# Altium schematic sheet Sheet3.SchDoc
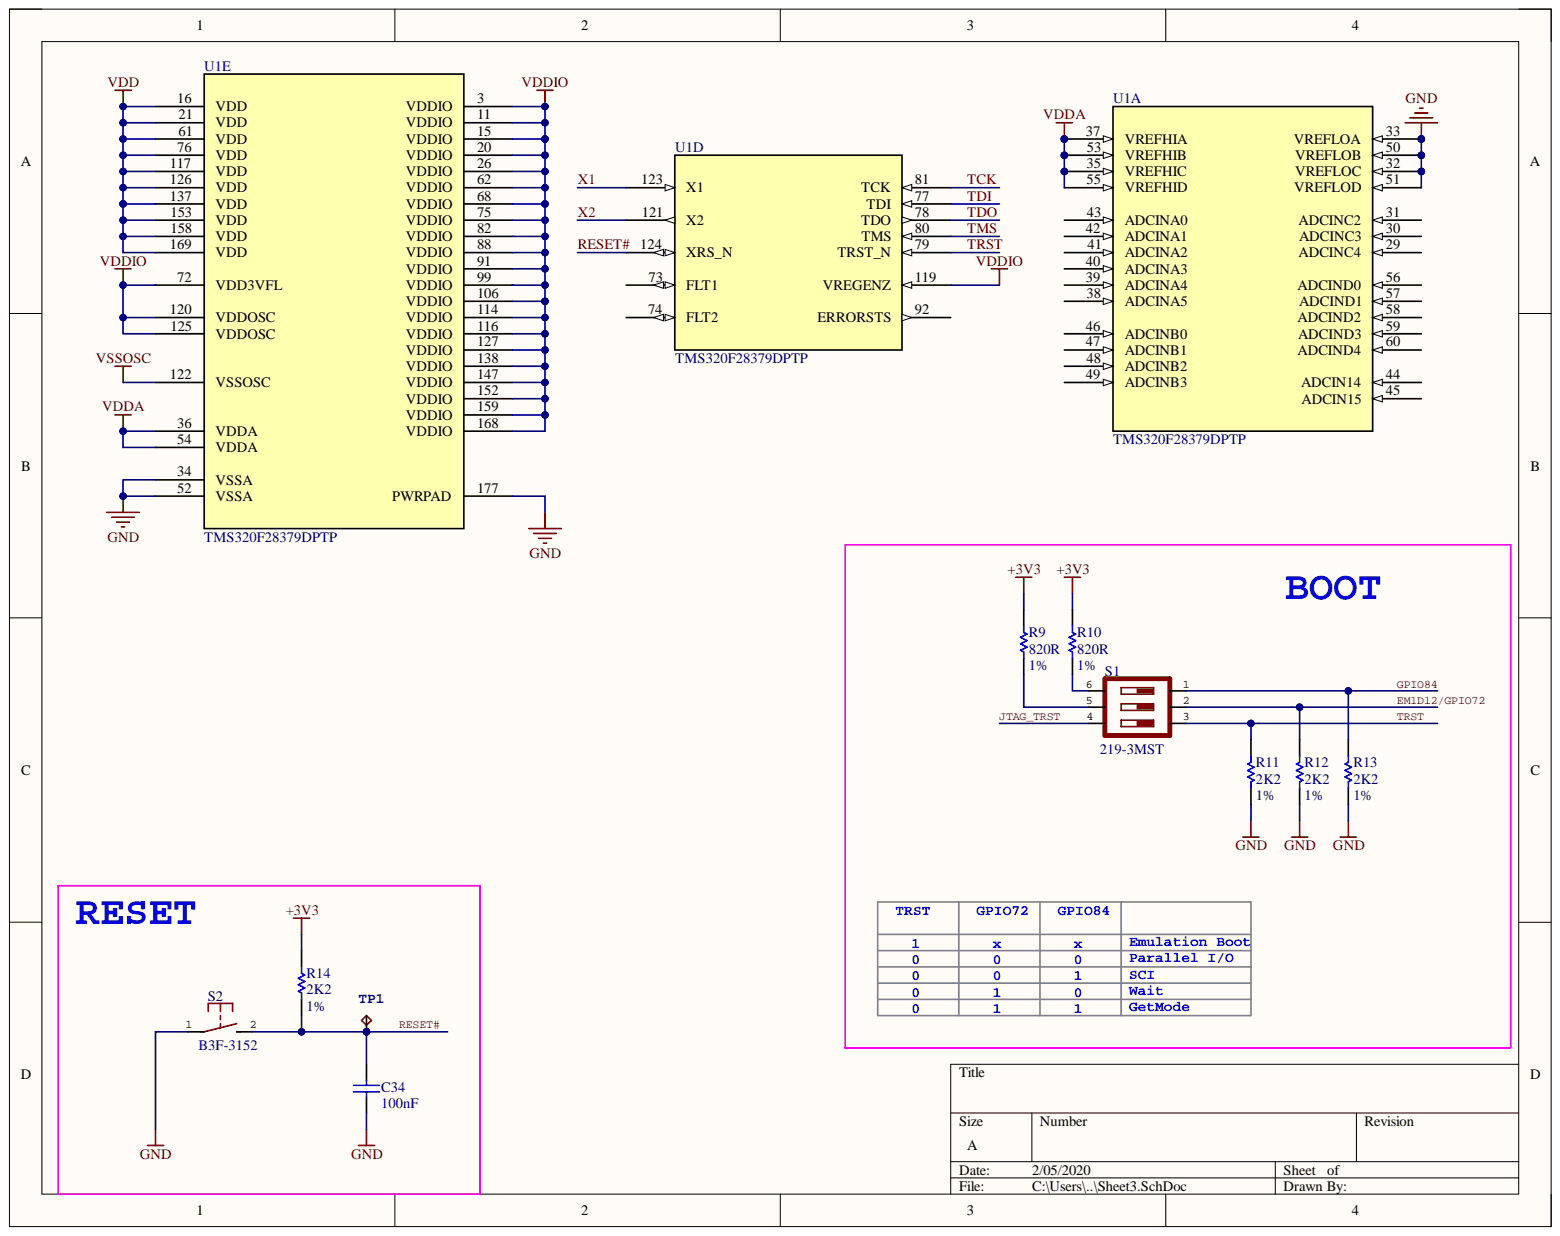
[diagram p0: Sheet3.SchDoc]

## Parts
C34  100nF  [C0402]
R9  820R  Rating: 1%  [R0402]
R10  820R  Rating: 1%  [R0402]
R11  2K2  Rating: 1%  [R0402]
R12  2K2  Rating: 1%  [R0402]
R13  2K2  Rating: 1%  [R0402]
R14  2K2  Rating: 1%  [R0402]
S1  219-3MST  [SW254P990X385-6N]
S2  B3F-3152  [SW4P730X625]
TP1  TESTPAD_TPB1,27  [TESTPAD_B1,27]  (value not drawn)
U1  TMS320F28379DPTP  [PTP0176F_L]  (parts A,D,E)

## Nets
+3V3: R9.2 R10.2 R14.2
GND: C34.2 R11.1 R12.1 R13.1 S2.1 U1.32(VREFLOC) U1.33(VREFLOA) U1.34(VSSA) U1.50(VREFLOB) U1.51(VREFLOD) U1.52(VSSA) U1.177(PWRPAD)
VDD: U1.16(VDD) U1.21(VDD) U1.61(VDD) U1.76(VDD) U1.117(VDD) U1.126(VDD) U1.137(VDD) U1.153(VDD) U1.158(VDD) U1.169(VDD)
VDDA: U1.35(VREFHIC) U1.36(VDDA) U1.37(VREFHIA) U1.53(VREFHIB) U1.54(VDDA) U1.55(VREFHID)
VDDIO: U1.3(VDDIO) U1.11(VDDIO) U1.15(VDDIO) U1.20(VDDIO) U1.26(VDDIO) U1.62(VDDIO) U1.68(VDDIO) U1.72(VDD3VFL) U1.75(VDDIO) U1.82(VDDIO) U1.88(VDDIO) U1.91(VDDIO) U1.99(VDDIO) U1.106(VDDIO) U1.114(VDDIO) U1.116(VDDIO) U1.119(VREGENZ) U1.120(VDDOSC) U1.125(VDDOSC) U1.127(VDDIO) U1.138(VDDIO) U1.147(VDDIO) U1.152(VDDIO) U1.159(VDDIO) U1.168(VDDIO)
VSSOSC: U1.122(VSSOSC)
EM1D12/GPIO72: R12.2 S1.2
GPIO84: R13.2 S1.1
JTAG_TRST: S1.4
RESET#: C34.1 R14.1 S2.2 TP1.TP U1.124(XRS_N)
TCK: U1.81(TCK)
TDI: U1.77(TDI)
TDO: U1.78(TDO)
TMS: U1.80(TMS)
TRST: R11.2 S1.3 U1.79(TRST_N)
X1: U1.123(X1)
X2: U1.121(X2)
NetR9_1: R9.1 S1.5
NetR10_1: R10.1 S1.6

Not connected: U1 29(ADCINC4) 30(ADCINC3) 31(ADCINC2) 38(ADCINA5) 39(ADCINA4) 40(ADCINA3) 41(ADCINA2) 42(ADCINA1) 43(ADCINA0) 44(ADCIN14) 45(ADCIN15) 46(ADCINB0) 47(ADCINB1) 48(ADCINB2) 49(ADCINB3) 56(ADCIND0) 57(ADCIND1) 58(ADCIND2) 59(ADCIND3) 60(ADCIND4) 73(FLT1) 74(FLT2) 92(ERRORSTS)

## Library data of the parts
C34 = 1004001100187: CAP,100NF(0.1uf),+/-10%,X5R,10V,SMD0402; Manufacturer: MURATA; Manufacturer Part Number: GRM155R61A104KA01D; Database Table Name: Capacitor$; Rating: 10%; Component Kind: Standard; Embest PN: 1004001100187; Part Type: Ceramic Capacitor; Value: 100nF; Library Name: Embest.DbLib
R9 R10 = 1003001100482: RES,820R,+/-1%,1/16W,SMD0402; Manufacturer: YAGEO; Manufacturer Part Number: RC0402FR-07820RL; Database Table Name: Resistor$; Component Kind: Standard; Embest PN: 1003001100482; Part Type: Thick film Resistor; Value: 820R; Library Name: Embest.DbLib
R11-R14 = 1003001100045: RES,2K2,+/-1%,1/16W,SMD0402; Manufacturer: YAGEO; Manufacturer Part Number: RC0402FR-072K2L; Database Table Name: Resistor$; Component Kind: Standard; Embest PN: 1003001100045; Part Type: Thick film Resistor; Value: 2K2; Library Name: Embest.DbLib
S1 = 1010003100003: Switch, DIP Switches,3 Position,2.54MM Pitch,black housing,white plunger,SMD; Manufacturer: CTS; Database Table Name: Switch$; Component Kind: Standard; Embest PN: 1010003100003; Manufacturer Part Number: 219-3MST; Part Type: DIP Switch; Value: 219-3MST; Library Name: Embest.DbLib
S2 = 1010001200049: SWITCH TACTILE SPST-NO 0.05A 24V; Manufacturer: OMRON; Database Table Name: Switch$; Component Kind: Standard; Embest PN: 1010001200049; Manufacturer Part Number: B3F-3152; Part Type: Tactile Switch; Value: B3F-3152; Library Name: Embest.DbLib
TP1 = TESTPAD_TPB1,27: Test pad; Component Kind: Standard; Library Name: Embest.DbLib
U1 = TMS320F28379DPTP: Imported; Manufacturer: Texas Instruments; PartNumber: TMS320F28379DPTPS; Component Kind: Standard; Library Name: TMS320F28379DPTPT.SchLib; RefDes: RefDes; TYPE: TYPE; Value: Value
